# Altium schematic sheet TPVSI_MOSFET_Driver.SchDoc(TPVSI_MOSFET_Driver)
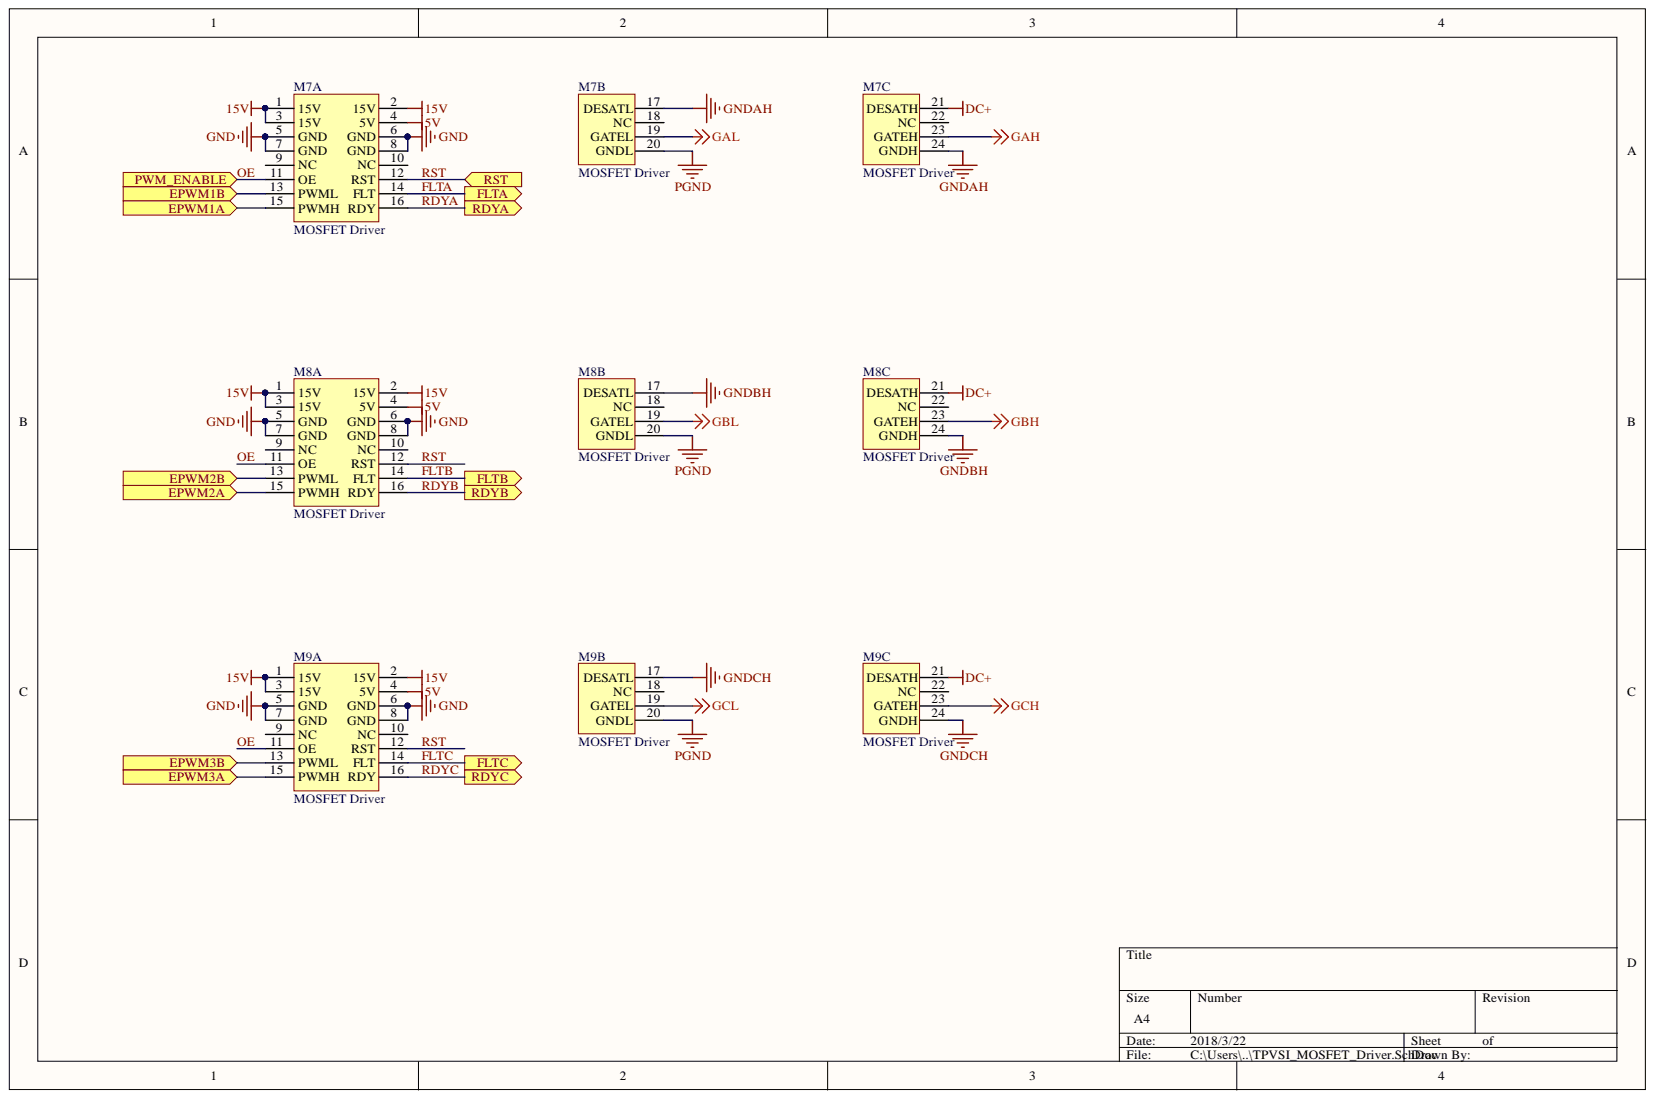
[diagram p0: TPVSI_MOSFET_Driver.SchDoc]

## Parts
M7  MOSFET Driver  [MOSFET_DRIVER]  (parts A,B,C)
M8  MOSFET Driver  [MOSFET_DRIVER]  (parts A,B,C)
M9  MOSFET Driver  [MOSFET_DRIVER]  (parts A,B,C)

## Ports
EPWM1A, EPWM1B, EPWM2A, EPWM2B, EPWM3A, EPWM3B, FLTA, FLTB, FLTC, PWM_ENABLE, RDYA, RDYB, RDYC, RST

## Nets
5V: M7.4(5V) M8.4(5V) M9.4(5V)
15V: M7.1(15V) M7.2(15V) M7.3(15V) M8.1(15V) M8.2(15V) M8.3(15V) M9.1(15V) M9.2(15V) M9.3(15V)
DC+: M7.21(DESATH) M8.21(DESATH) M9.21(DESATH)
GAH: M7.23(GATEH)
GAL: M7.19(GATEL)
GBH: M8.23(GATEH)
GBL: M8.19(GATEL)
GCH: M9.23(GATEH)
GCL: M9.19(GATEL)
GND: M7.5(GND) M7.6(GND) M7.7(GND) M7.8(GND) M8.5(GND) M8.6(GND) M8.7(GND) M8.8(GND) M9.5(GND) M9.6(GND) M9.7(GND) M9.8(GND)
GNDAH: M7.17(DESATL) M7.24(GNDH)
GNDBH: M8.17(DESATL) M8.24(GNDH)
GNDCH: M9.17(DESATL) M9.24(GNDH)
PGND: M7.20(GNDL) M8.20(GNDL) M9.20(GNDL)
EPWM1A: M7.15(PWMH) ; port EPWM1A
EPWM1B: M7.13(PWML) ; port EPWM1B
EPWM2A: M8.15(PWMH) ; port EPWM2A
EPWM2B: M8.13(PWML) ; port EPWM2B
EPWM3A: M9.15(PWMH) ; port EPWM3A
EPWM3B: M9.13(PWML) ; port EPWM3B
FLTA: M7.14(FLT) ; port FLTA
FLTB: M8.14(FLT) ; port FLTB
FLTC: M9.14(FLT) ; port FLTC
OE: M7.11(OE) M8.11(OE) M9.11(OE) ; port PWM_ENABLE
RDYA: M7.16(RDY) ; port RDYA
RDYB: M8.16(RDY) ; port RDYB
RDYC: M9.16(RDY) ; port RDYC
RST: M7.12(RST) M8.12(RST) M9.12(RST) ; port RST

Not connected: M7 9(NC) 10(NC) 18(NC) 22(NC); M8 9(NC) 10(NC) 18(NC) 22(NC); M9 9(NC) 10(NC) 18(NC) 22(NC)

## Library data of the parts
M7-M9 = MOSFET_Driver: Component Kind: Standard; Library Name: Chu_16.IntLib
